# Altium schematic sheet Schedino_RIK.SchDoc(Schedino_RIK)
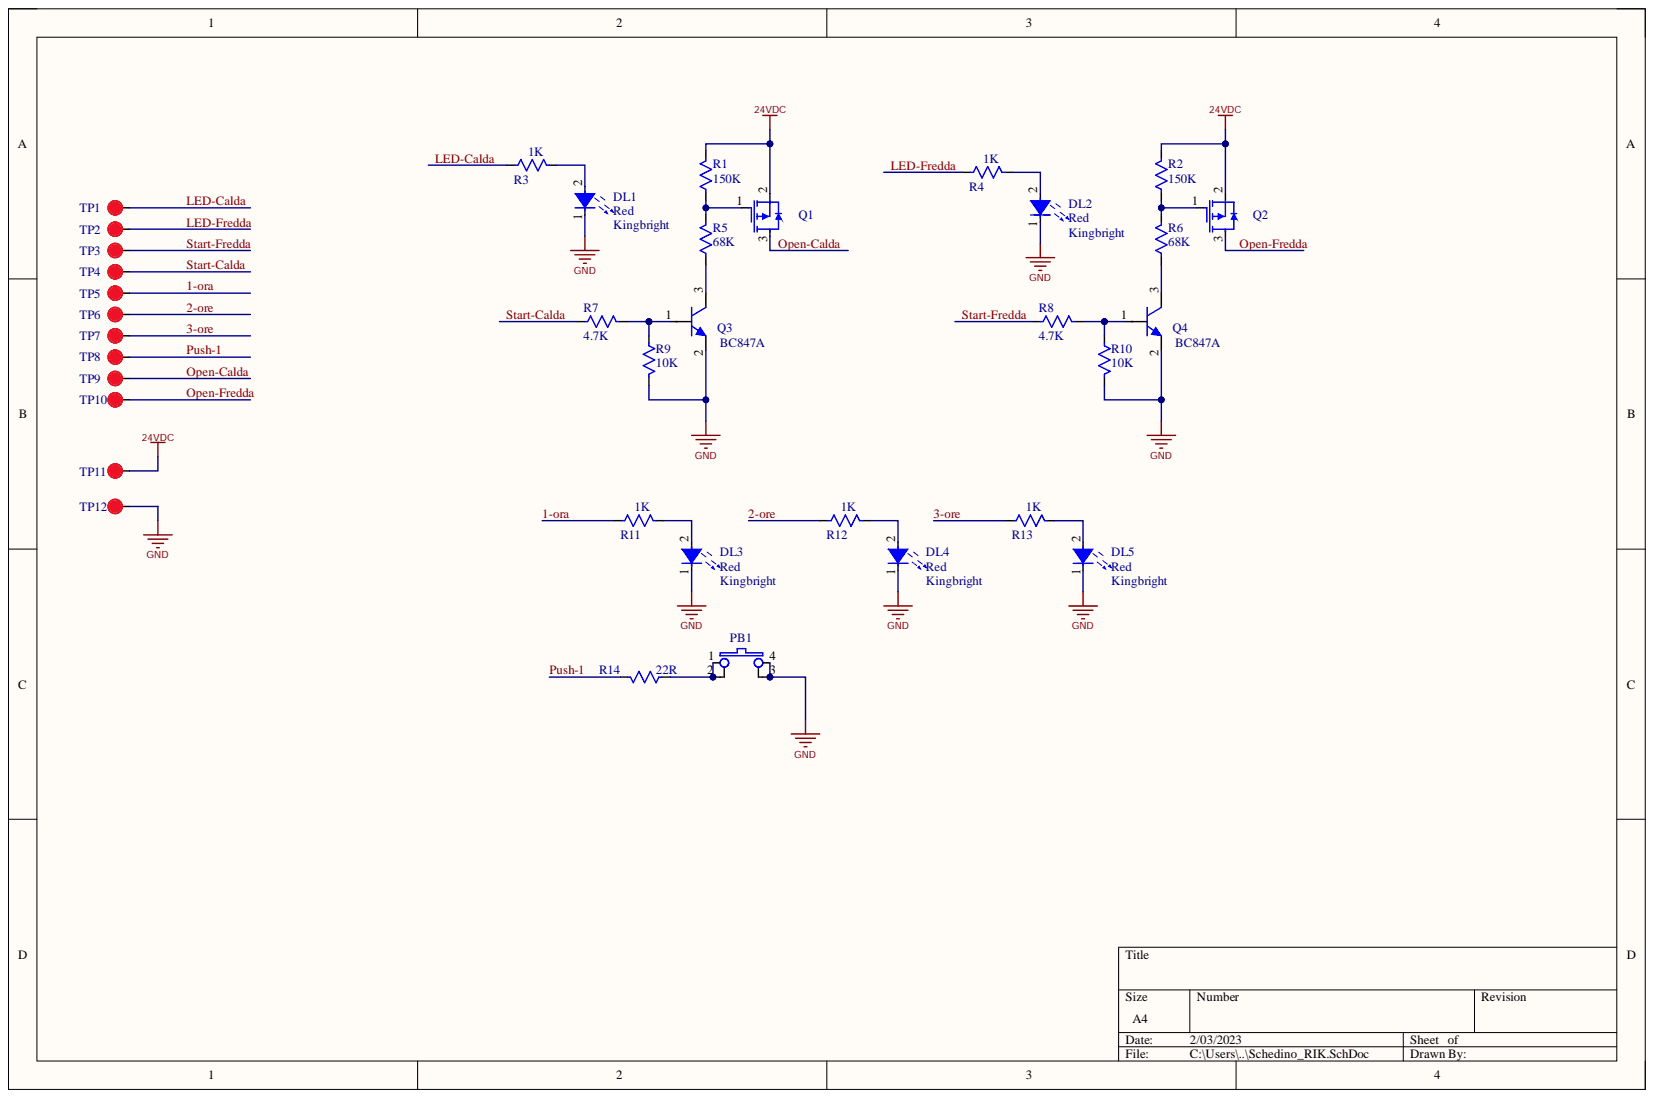
[diagram p0: Schedino_RIK.SchDoc]

## Parts
DL1  COLOUR: Red  MAKER: Kingbright  [HL_KPTD-3216]  (hidden comment: HL_KPTD-3216)
DL2  COLOUR: Red  MAKER: Kingbright  [HL_KPTD-3216]  (hidden comment: HL_KPTD-3216)
DL3  COLOUR: Red  MAKER: Kingbright  [HL_KPTD-3216]  (hidden comment: HL_KPTD-3216)
DL4  COLOUR: Red  MAKER: Kingbright  [HL_KPTD-3216]  (hidden comment: HL_KPTD-3216)
DL5  COLOUR: Red  MAKER: Kingbright  [HL_KPTD-3216]  (hidden comment: HL_KPTD-3216)
PB1  ST_DTSM-6_1_R  [ST_DTSM-6_1_R]  (value not drawn)
Q1  1.9.10.018.00  [SOT-23BS-R0M]  (value not drawn)
Q2  1.9.10.018.00  [SOT-23BS-R0M]  (value not drawn)
Q3  PN: BC847A  [sot-23bs-r0m]  (hidden comment: 1.9.09.029.00)
Q4  PN: BC847A  [sot-23bs-r0m]  (hidden comment: 1.9.09.029.00)
R1  150K  [R_XP0603-R0M]
R2  150K  [R_XP0603-R0M]
R3  1K  [R_XP0603-R0M]
R4  1K  [R_XP0603-R0M]
R5  68K  [R_XP0603-R0M]
R6  68K  [R_XP0603-R0M]
R7  4.7K  [R_XP0603-R0M]
R8  4.7K  [R_XP0603-R0M]
R9  10K  [R_XP0603-R0M]
R10  10K  [R_XP0603-R0M]
R11  1K  [R_XP0603-R0M]
R12  1K  [R_XP0603-R0M]
R13  1K  [R_XP0603-R0M]
R14  22R  [R_XP0603-R0M]
TP1  TP_2mm  [TP_2.0]  (value not drawn)  (no BOM)
TP2  TP_2mm  [TP_2.0]  (value not drawn)  (no BOM)
TP3  TP_2mm  [TP_2.0]  (value not drawn)  (no BOM)
TP4  TP_2mm  [TP_2.0]  (value not drawn)  (no BOM)
TP5  TP_2mm  [TP_2.0]  (value not drawn)  (no BOM)
TP6  TP_2mm  [TP_2.0]  (value not drawn)  (no BOM)
TP7  TP_2mm  [TP_2.0]  (value not drawn)  (no BOM)
TP8  TP_2mm  [TP_2.0]  (value not drawn)  (no BOM)
TP9  TP_2mm  [TP_2.0]  (value not drawn)  (no BOM)
TP10  TP_2mm  [TP_2.0]  (value not drawn)  (no BOM)
TP11  TP_2mm  [TP_2.0]  (value not drawn)  (no BOM)
TP12  TP_2mm  [TP_2.0]  (value not drawn)  (no BOM)

## Nets
24VDC: Q1.2 Q2.2 R1.1 R2.1 TP11.1
GND: DL1.1 DL2.1 DL3.1 DL4.1 DL5.1 PB1.3 PB1.4 Q3.2 Q4.2 R9.2 R10.2 TP12.1
1-ora: R11.2 TP5.1
2-ore: R12.2 TP6.1
3-ore: R13.2 TP7.1
LED-Calda: R3.2 TP1.1
LED-Fredda: R4.2 TP2.1
Open-Calda: Q1.3 TP9.1
Open-Fredda: Q2.3 TP10.1
Push-1: R14.1 TP8.1
Start-Calda: R7.1 TP4.1
Start-Fredda: R8.1 TP3.1
NetDL1_2: DL1.2 R3.1
NetDL2_2: DL2.2 R4.1
NetDL3_2: DL3.2 R11.1
NetDL4_2: DL4.2 R12.1
NetDL5_2: DL5.2 R13.1
NetPB1_1: PB1.1 PB1.2 R14.2
NetQ1_1: Q1.1 R1.2 R5.1
NetQ2_1: Q2.1 R2.2 R6.1
NetQ3_1: Q3.1 R7.2 R9.1
NetQ3_3: Q3.3 R5.2
NetQ4_1: Q4.1 R8.2 R10.1
NetQ4_3: Q4.3 R6.2

## Library data of the parts
DL1-DL5 = 1.9.13.006.00: 3,2x1,6mm (1206) SMD High Brightness InGaAlP Red Chip Led Lamp / Luminous Intensity: Iv=180mcd(min.) 500mcd(typ.) at 20mA / Viewing Angle 50°; ALT_1: NA; CmpIndex: 10; Database Table Name: Leds; MAKER_PN: KPTD-3216SURCK; RSL COMPLIANT: YES; TJ: -40°C to 85°C (Operating Temperature); ALT_2: NA; ALT_3: NA; ALT_4: NA; ALT_5: NA; CASE: 3,2x1,6mm (1206); Thickness: 1,8mm; Component Kind: Standard; DXDB_LIBNAME: Leds; MOUNTED: YES; MOUNTING_TYPE: SMD; Orientation: 0 = 0; PN: KPTD-3216SURCK; SAECO_PN: 1.9.13.006.00; STATUS: SCONSIGLIATO; TECHNOLOGY: InGaAlP on GaAs; Library Name: Db_Gaggio_Altium.DbLib
PB1 = 1.9.27.026.00: Vertical SMD Square Tact Switch 6x6mm (stem height: 4,3mm) / 50mA / 12V / Operating Force: 260gf / Travel: 0,25mm; ALT_1: NA; Case_Dim: 6,2x6,2mm; stem height: 4,3mm; Database Table Name: Switches_Pushbuttons; DXDB_LIBNAME: Switches-Pushbuttons; LEVEL: STD; MAKER: Diptronics, E-Switch, Himake, Tyco-Alcoswitch; MAKER_PN: DTSM-6 1 R-V, TL-3301-N-F260-Q-G, TSSA-32L, FSM2JSMAA (2-1437565); Symbol: pushbutton1; TRAVEL: 0,25mm; ALT_2: NA; ALT_3: NA; ALT_4: NA; ALT_5: NA; CASE: 6,2x6,2mm; stem height: 4,3mm; Component Kind: Standard; IRMS: 50mA; MOIUNTING TYPE: SMD; MOUNTED: YES; MOUNTING_TYPE: SMD; OPEN_FORCE: 260gf; SAECO_PN: 1.9.27.026.00; STATUS: SCONSIGLIATO; TYPE: Tact Switch; VDC: 12V; Library Name: Db_Gaggio_Altium.DbLib
Q1 Q2 = 1.9.10.018.00: SOT-23 P-Channel Logic Level Mosfet / Vds=-30V / Id=-3A / Rds(0n)=0,165 Ohm (MAX.) / Vgs(th)=-1V (MIN.); ALT_1: 1.9.10.093.00; ALT_2: NA; CmpIndex: 3; Database Table Name: Mosfets; DEVICE: P_MOS_SOT23_GSD; ID: -3A (DC Drain Current at 25°C, Vgs=-10V); MAKER: Infineon; MAKER_PN: IRLML5203PBF; MOUNTING_TYPE: SMD; PN: IRLML5203; Rds(on): 0,072 Ohm (MAX. with Vgs=-4,5V, Id=-2,3A, Ta=25°); RDS_ON: 1,1 Ohm (MAX. with Vgs=-4,5V; Id=-0,5A; Ta=25°); RSL COMPLIANT: YES; SymIndex: 250; TJ: 150°C (MAX.); VDS: -30V (Drain Source Voltage with Vgs=0V); ALT_3: NA; ALT_4: NA; ALT_5: NA; CASE: SOT-23; Component Kind: Standard; DXDB_LIBNAME: Mosfets; MOUNTED: YES; Orientation: 0 = 0; SAECO_PN: 1.9.10.018.00; STATUS: RACCOMANDATO; Library Name: Db_Gaggio_Altium.DbLib
Q3 Q4 = 1.9.09.029.00: NPN Silicon General Purpose Transistor in a SOT-23 Plastic Package / Collector-Emitter Voltage Vceo=45V / DC Collector Current Ic=100mA / hFE=100 (MIN.); ALT_1: NA; CmpIndex: 1; Database Table Name: Transistors; DEVICE: BJT_NPN_SOT23_BEC; IC: 100mA (DC Collector Current); MAKER: Diodes, On Semiconductor, MCC, Nexperia, Taiwan Semiconductor; MAKER_PN: BC847A-F, BC847ALT1G, BC847A-TP-HF, BC847A, BC847A-G; MOUNTING_TYPE: SMD; RSL COMPLIANT: YES; SymIndex: 256; TJ: 150°C (MAX.); VCE: 45V (Collector Emitter Voltage with Ib=0A); ALT_2: NA; ALT_3: NA; ALT_4: NA; ALT_5: NA; CASE: SOT-23; Component Kind: Standard; DXDB_LIBNAME: Transistors; MOUNTED: YES; Orientation: 0 = 0; SAECO_PN: 1.9.09.029.00; STATUS: RACCOMANDATO; Library Name: Db_Gaggio_Altium.DbLib
R1 R2 = 1.9.01.440.00: Res. 0603 150K / 5% / 0,0625W; ALT_1: NA; CmpIndex: 17; Database Table Name: Resistors; DEVICE: R_RA02208; MAKER: Firstohm; Kamaya; Royal Ohm; Uniroyal; Vishay; Walsin; Yageo; MAKER_PN: CP06 Series; RMC1/16 Series; 0603WG, 0603SA Series; 0603WG, 0603SA Series; CHP0603, CRCW0603 Series; WR06X Series; RC0603 Series; MOUNTING_TYPE: SMD; SymIndex: 101; ALT_2: NA; ALT_3: NA; ALT_4: NA; ALT_5: NA; CASE: 0603; Component Kind: Standard; DXDB_LIBNAME: Resistors; MOUNTED: YES; Orientation: 0=0; Price: 0,02; PWR_RATING: 0,0625W; SAECO_PN: 1.9.01.440.00; STATUS: RACCOMANDATO; TECHNOLOGY: Thick Film; TOLERANCE: 5%; VALUE: 150K; Library Name: Db_Gaggio_Altium.DbLib
R3 R4 R11-R13 = 1.9.01.414.00: Res. 0603 1K / 5% / 0,0625W; ALT_1: NA; CmpIndex: 17; Database Table Name: Resistors; DEVICE: R_RA02208; MAKER: Firstohm; Kamaya; Royal Ohm; Uniroyal; Vishay; Walsin; Yageo; MAKER_PN: CP06 Series; RMC1/16 Series; 0603WG, 0603SA Series; 0603WG, 0603SA Series; CHP0603, CRCW0603 Series; WR06X Series; RC0603 Series; MOUNTING_TYPE: SMD; SymIndex: 101; ALT_2: NA; ALT_3: NA; ALT_4: NA; ALT_5: NA; CASE: 0603; Component Kind: Standard; DXDB_LIBNAME: Resistors; MOUNTED: YES; Orientation: 0=0; Price: 0,02; PWR_RATING: 0,0625W; SAECO_PN: 1.9.01.414.00; STATUS: RACCOMANDATO; TECHNOLOGY: Thick Film; TOLERANCE: 5%; VALUE: 1K; Library Name: Db_Gaggio_Altium.DbLib
R5 R6 = 1.9.01.436.00: Res. 0603 68K / 5% / 0,0625W; ALT_1: NA; CmpIndex: 17; Database Table Name: Resistors; DEVICE: R_RA02208; MAKER: Firstohm; Kamaya; Royal Ohm; Uniroyal; Vishay; Walsin; Yageo; MAKER_PN: CP06 Series; RMC1/16 Series; 0603WG, 0603SA Series; 0603WG, 0603SA Series; CHP0603, CRCW0603 Series; WR06X Series; RC0603 Series; MOUNTING_TYPE: SMD; SymIndex: 101; ALT_2: NA; ALT_3: NA; ALT_4: NA; ALT_5: NA; CASE: 0603; Component Kind: Standard; DXDB_LIBNAME: Resistors; MOUNTED: YES; Orientation: 0=0; Price: 0,02; PWR_RATING: 0,0625W; SAECO_PN: 1.9.01.436.00; STATUS: RACCOMANDATO; TECHNOLOGY: Thick Film; TOLERANCE: 5%; VALUE: 68K; Library Name: Db_Gaggio_Altium.DbLib
R7 R8 = 1.9.01.422.00: Res. 0603 4K7 / 5% / 0,0625W; ALT_1: NA; CmpIndex: 17; Database Table Name: Resistors; DEVICE: R_RA02208; MAKER: Firstohm; Kamaya; Royal Ohm; Uniroyal; Vishay; Walsin; Yageo; MAKER_PN: CP06 Series; RMC1/16 Series; 0603WG, 0603SA Series; 0603WG, 0603SA Series; CHP0603, CRCW0603 Series; WR06X Series; RC0603 Series; MOUNTING_TYPE: SMD; SymIndex: 101; ALT_2: NA; ALT_3: NA; ALT_4: NA; ALT_5: NA; CASE: 0603; Component Kind: Standard; DXDB_LIBNAME: Resistors; MOUNTED: YES; Orientation: 0=0; Price: 0,02; PWR_RATING: 0,0625W; SAECO_PN: 1.9.01.422.00; STATUS: RACCOMANDATO; TECHNOLOGY: Thick Film; TOLERANCE: 5%; VALUE: 4.7K; Library Name: Db_Gaggio_Altium.DbLib
R9 R10 = 1.9.01.426.00: Res. 0603 10K / 5% / 0,0625W; ALT_1: NA; CmpIndex: 17; Database Table Name: Resistors; DEVICE: R_RA02208; MAKER: Firstohm; Kamaya; Royal Ohm; Uniroyal; Vishay; Walsin; Yageo; MAKER_PN: CP06 Series; RMC1/16 Series; 0603WG, 0603SA Series; 0603WG, 0603SA Series; CHP0603, CRCW0603 Series; WR06X Series; RC0603 Series; MOUNTING_TYPE: SMD; SymIndex: 101; ALT_2: NA; ALT_3: NA; ALT_4: NA; ALT_5: NA; CASE: 0603; Component Kind: Standard; DXDB_LIBNAME: Resistors; MOUNTED: YES; Orientation: 0=0; Price: 0,02; PWR_RATING: 0,0625W; SAECO_PN: 1.9.01.426.00; STATUS: RACCOMANDATO; TECHNOLOGY: Thick Film; TOLERANCE: 5%; VALUE: 10K; Library Name: Db_Gaggio_Altium.DbLib
R14 = 1.9.01.394.00: Res. 0603 22R / 5% / 0,0625W; ALT_1: NA; Database Table Name: Resistors; MAKER: Firstohm; Kamaya; Royal Ohm; Uniroyal; Vishay; Yageo; MAKER_PN: CP06 Series; RMC1/16 Series; 0603WG, 0603SA Series; 0603WG, 0603SA Series; CHP0603, CRCW0603 Series; RC0603 Series; MOUNTING_TYPE: SMD; ALT_2: NA; ALT_3: NA; ALT_4: NA; ALT_5: NA; CASE: 0603; Component Kind: Standard; DXDB_LIBNAME: Resistors; MOUNTED: YES; Price: 0,02; PWR_RATING: 0,0625W; SAECO_PN: 1.9.01.394.00; STATUS: RACCOMANDATO; TECHNOLOGY: Thick Film; TOLERANCE: 5%; VALUE: 22R; Library Name: Db_Gaggio_Altium.DbLib
TP1-TP12 = TP_2mm: PCB Testpoint; Checked_By: Hamin; Drawn_By: Zvonc; Note: 1.2mm; BL Part Number: N/A; DateModified: 24-04-2018; Library Name: TP&Mechanical.SCHLIB; Manufacturer: N/A; Manufacturer Part Number: N/A
